# Altium schematic sheet FPGA_MISC.SchDoc(FPGA_MISC)
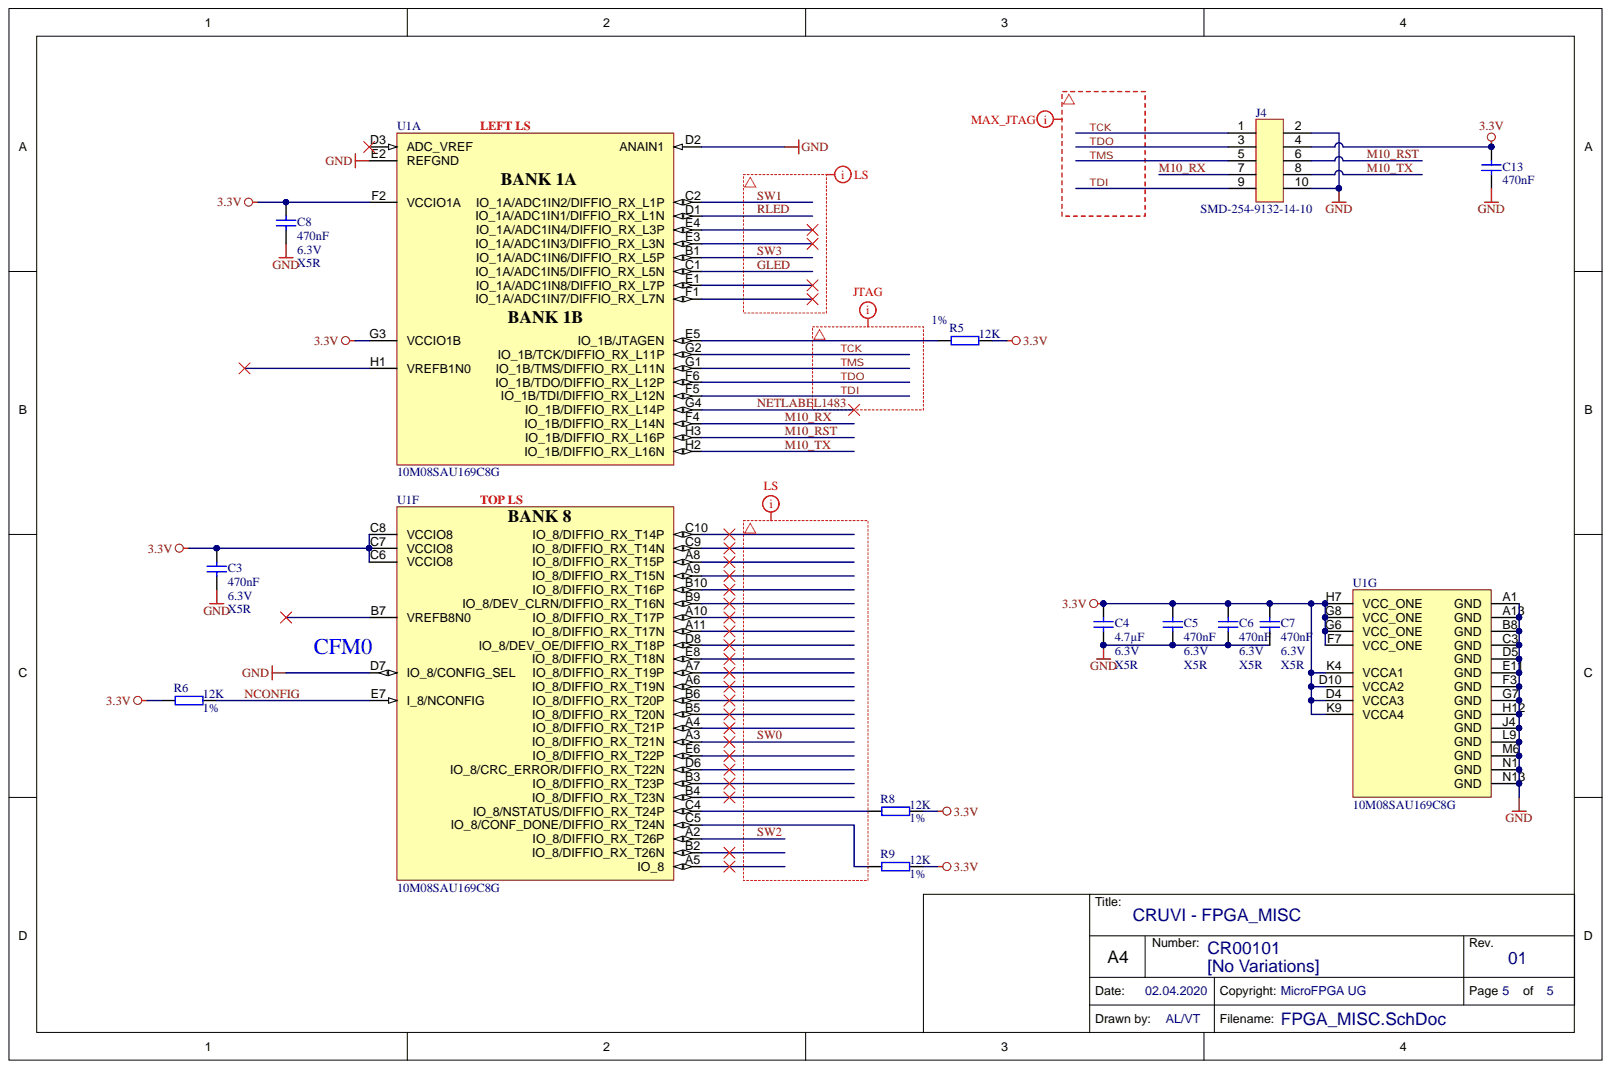
[diagram p0: FPGA_MISC.SchDoc]

## Parts
C3  470nF  Technology: X5R  Voltage: 6.3V  [CAPC0603X33N]
C4  4.7µF  Technology: X5R  Voltage: 6.3V  [CAPC1005X60N]
C5  470nF  Technology: X5R  Voltage: 6.3V  [CAPC0603X33N]
C6  470nF  Technology: X5R  Voltage: 6.3V  [CAPC0603X33N]
C7  470nF  Technology: X5R  Voltage: 6.3V  [CAPC0603X33N]
C8  470nF  Technology: X5R  Voltage: 6.3V  [CAPC0603X33N]
C13  470nF  [CAPC0603X33N]
J4  SMD-254-9132-14-10  [SMD-254-9132-14-10]
R5  12K  Tolerance: 1%  [RESC1005X40N]
R6  12K  Tolerance: 1%  [RESC1005X40N]
R8  12K  Tolerance: 1%  [RESC1005X40N]
R9  12K  Tolerance: 1%  [RESC1005X40N]
U1  10M08SAU169C8G  [BGA169C80P13X13_11X11X15]  (parts A,F,G)

## Nets
3.3V: C3.1 C4.1 C5.1 C6.1 C7.1 C8.1 C13.1 J4.4 R5.2 R6.1 R8.2 R9.2 U1.C6(VCCIO8) U1.C7(VCCIO8) U1.C8(VCCIO8) U1.D4(VCCA3) U1.D10(VCCA2) U1.F2(VCCIO1A) U1.F7(VCC_ONE) U1.G3(VCCIO1B) U1.G6(VCC_ONE) U1.G8(VCC_ONE) U1.H7(VCC_ONE) U1.K4(VCCA1) U1.K9(VCCA4)
GND: C3.2 C4.2 C5.2 C6.2 C7.2 C8.2 C13.2 J4.2 J4.10 U1.A1(GND) U1.A13(GND) U1.B8(GND) U1.C3(GND) U1.D2(ANAIN1) U1.D5(GND) U1.D7(IO_8/CONFIG_SEL) U1.E2(REFGND) U1.E11(GND) U1.F3(GND) U1.G7(GND) U1.H12(GND) U1.J4(GND) U1.L9(GND) U1.M6(GND) U1.N1(GND) U1.N13(GND)
GLED: U1.C1(IO_1A/ADC1IN5/DIFFIO_RX_L5N)
M10_RST: J4.6 U1.H3(IO_1B/DIFFIO_RX_L16P)
M10_RX: J4.7 U1.F4(IO_1B/DIFFIO_RX_L14N)
M10_TX: J4.8 U1.H2(IO_1B/DIFFIO_RX_L16N)
NCONFIG: R6.2 U1.E7(I_8/NCONFIG)
NETLABEL1483: U1.G4(IO_1B/DIFFIO_RX_L14P)
RLED: U1.D1(IO_1A/ADC1IN1/DIFFIO_RX_L1N)
SW0: U1.A3(IO_8/DIFFIO_RX_T21N)
SW1: U1.C2(IO_1A/ADC1IN2/DIFFIO_RX_L1P)
SW2: U1.A2(IO_8/DIFFIO_RX_T26P)
SW3: U1.B1(IO_1A/ADC1IN6/DIFFIO_RX_L5P)
TCK: J4.1 U1.G2(IO_1B/TCK/DIFFIO_RX_L11P)
TDI: J4.9 U1.F5(IO_1B/TDI/DIFFIO_RX_L12N)
TDO: J4.3 U1.F6(IO_1B/TDO/DIFFIO_RX_L12P)
TMS: J4.5 U1.G1(IO_1B/TMS/DIFFIO_RX_L11N)
NetR5_1: R5.1 U1.E5(IO_1B/JTAGEN)
NetR8_1: R8.1 U1.C4(IO_8/NSTATUS/DIFFIO_RX_T24P)
NetR9_1: R9.1 U1.C5(IO_8/CONF_DONE/DIFFIO_RX_T24N)
N00434:
N00435:
N00436:
N00437:

Not connected: U1 A4(IO_8/DIFFIO_RX_T21P) A5(IO_8) A6(IO_8/DIFFIO_RX_T19N) A7(IO_8/DIFFIO_RX_T19P) A8(IO_8/DIFFIO_RX_T15P) A9(IO_8/DIFFIO_RX_T15N) A10(IO_8/DIFFIO_RX_T17P) A11(IO_8/DIFFIO_RX_T17N) B2(IO_8/DIFFIO_RX_T26N) B3(IO_8/DIFFIO_RX_T23P) B4(IO_8/DIFFIO_RX_T23N) B5(IO_8/DIFFIO_RX_T20N) B6(IO_8/DIFFIO_RX_T20P) B7(VREFB8N0) B9(IO_8/DEV_CLRN/DIFFIO_RX_T16N) B10(IO_8/DIFFIO_RX_T16P) C9(IO_8/DIFFIO_RX_T14N) C10(IO_8/DIFFIO_RX_T14P) D3(ADC_VREF) D6(IO_8/CRC_ERROR/DIFFIO_RX_T22N) D8(IO_8/DEV_OE/DIFFIO_RX_T18P) E1(IO_1A/ADC1IN8/DIFFIO_RX_L7P) E3(IO_1A/ADC1IN3/DIFFIO_RX_L3N) E4(IO_1A/ADC1IN4/DIFFIO_RX_L3P) E6(IO_8/DIFFIO_RX_T22P) E8(IO_8/DIFFIO_RX_T18N) F1(IO_1A/ADC1IN7/DIFFIO_RX_L7N) H1(VREFB1N0)

## Library data of the parts
C3 C5-C8 C13 = 24921: KON 470nF 6.3V X5R 20%  SMD BF 0603 (0201); Database Table Name: C_0603_0201; Mixed: SMT; Preferred: Yes; Temperature-Range: -55/+85; Value: 470n; AN: 24921; Case: 0603 (0201); Component Kind: Standard; Library Name: TE.DbLib; Tolerance: 20%
  C13: Technology: X5R; Voltage: 6.3V
C4 = 24719: KON 4.7µF 6.3V X5R 20% SMD BF 1005 (0402); Database Table Name: C_1005_0402; Mixed: SMT; Preferred: Yes; Temperature-Range: -55/+85; Value: 4.7u; AN: 24719; Case: 1005 (0402); Component Kind: Standard; Library Name: TE.DbLib; Tolerance: 20%
J4 = 22741: Stiftleiste gerade 2-reihig, 10pol. SMD; Database Table Name: CON_HEADER; H-ART: 9132-14-10-00-0-00; Mixed: HAND; Trenz-Stock: Yes; AN: 22741; Component Kind: Standard; Library Name: TE.DbLib; HelpURL: B:\AD\adlib\datasheet\9532_9132
R5 R6 R8 R9 = 22598: WID SMD 12K 1% 0,063W  BF 1005 (0402); Database Table Name: R_1005_0402; Mixed: SMT; Preferred: Yes; AN: 22598; Case: 1005 (0402); Component Kind: Standard; Library Name: TE.DbLib; Technology: TK100; Value: 12K
U1 = 27930: Database Table Name: PROG_LOGIC; Mixed: SMT; Temperature-Range: 0/+85 (Tj); AN: 27930; Case: LFBGA169; Component Kind: Standard; Description: 10M08SAU169C8G; H-ART: 10M08SAU169C8G; Library Name: TE.DbLib; Storage-Temperature: -65/+150(Abs); HelpURL: b:\AD\adlib\datasheet\ALTERA_MAX10\
